# Altium schematic sheet AX5243_schema.SchDoc
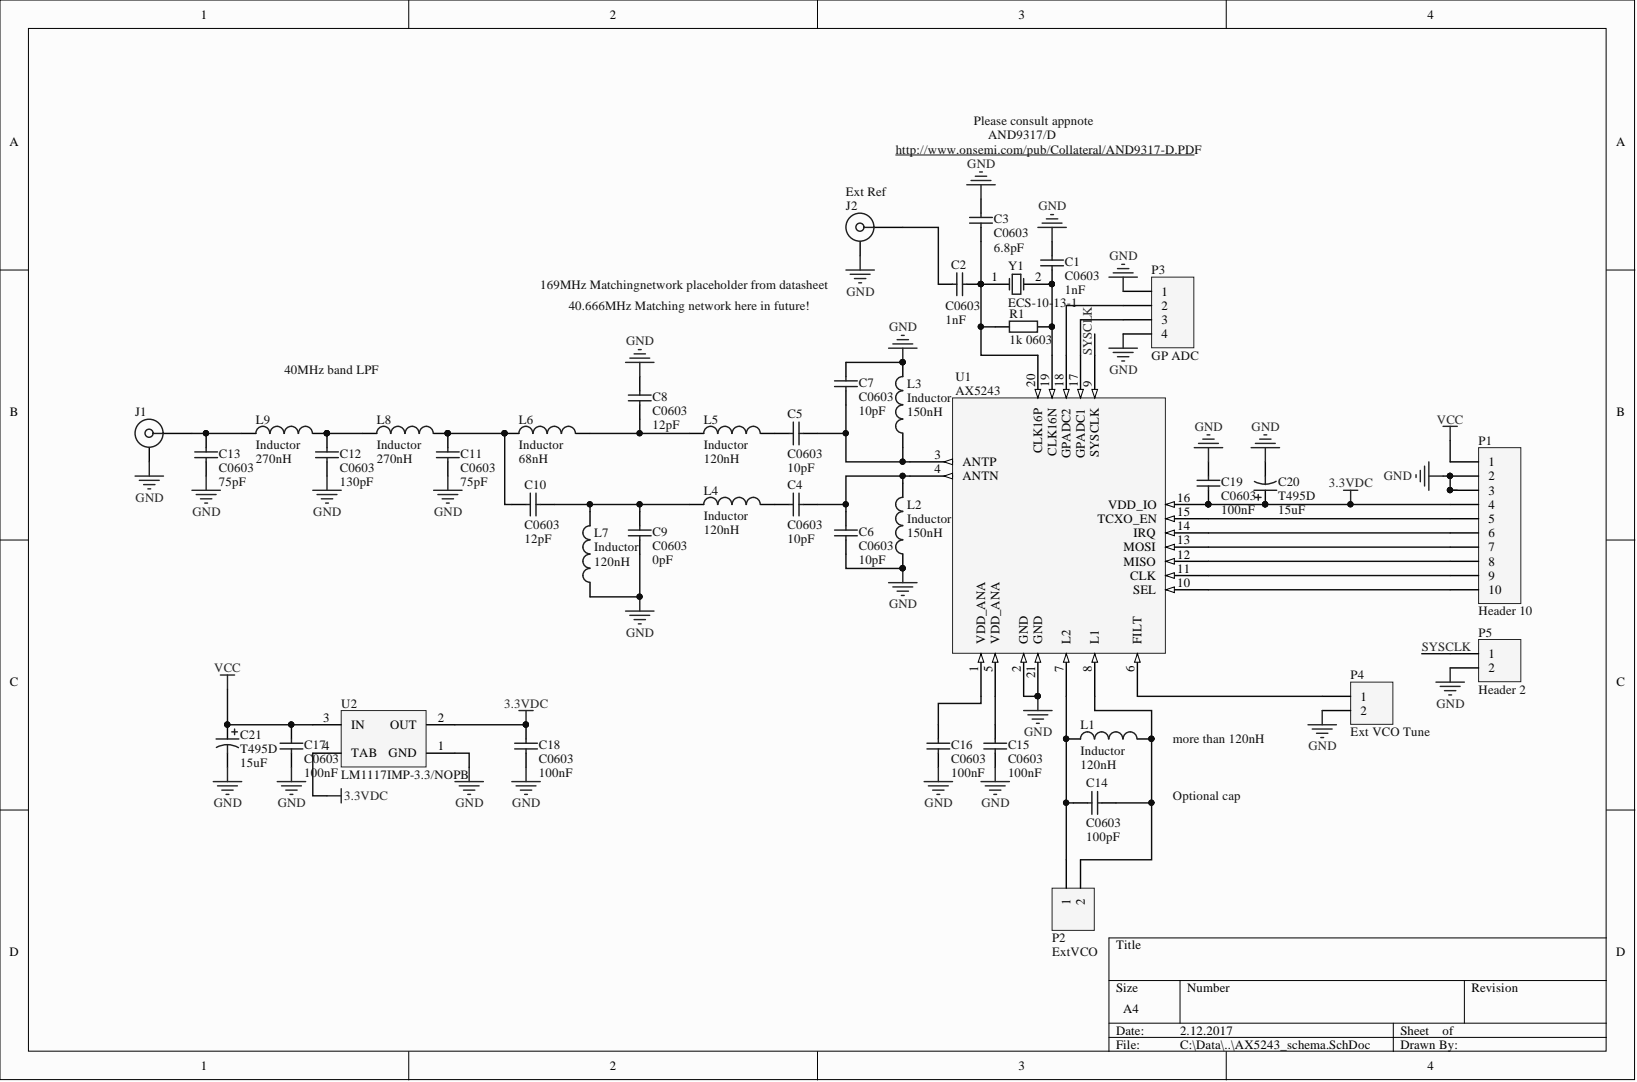
[diagram p0: AX5243_schema.SchDoc]

## Parts
C1  C0603  value 1nF  [0603]
C2  C0603  value 1nF  [0603]
C3  C0603  value 6.8pF  [0603]
C4  C0603  value 10pF  [0603]
C5  C0603  value 10pF  [0603]
C6  C0603  value 10pF  [0603]
C7  C0603  value 10pF  [0603]
C8  C0603  value 12pF  [0603]
C9  C0603  value 0pF  [0603]
C10  C0603  value 12pF  [0603]
C11  C0603  value 75pF  [0603]
C12  C0603  value 130pF  [0603]
C13  C0603  value 75pF  [0603]
C14  C0603  value 100pF  [0603]
C15  C0603  value 100nF  [0603]
C16  C0603  value 100nF  [0603]
C17  C0603  value 100nF  [0603]
C18  C0603  value 100nF  [0603]
C19  C0603  value 100nF  [0603]
C20  T495D  value 15uF  [D]
C21  T495D  value 15uF  [D]
J1  32K145-400L5  [ROS-32K145-400L5_V]  (value not drawn)
J2  32K10A-40ML5  [ROS-32K10A-40ML5_V]  (value not drawn)
L1  Inductor  value 120nH  [INDC1608L]
L2  Inductor  value 150nH  [INDC1608L]
L3  Inductor  value 150nH  [INDC1608L]
L4  Inductor  value 120nH  [INDC1608L]
L5  Inductor  value 120nH  [INDC1608L]
L6  Inductor  value 68nH  [INDC1608L]
L7  Inductor  value 120nH  [INDC1608L]
L8  Inductor  value 270nH  [INDC1608L]
L9  Inductor  value 270nH  [INDC1608L]
P1  Header 10  [HDR1X10]
P2  ExtVCO  [HDR1X2]
P3  GP ADC  [HDR1X4]
P4  Ext VCO Tune  [HDR1X2]
P5  Header 2  [HDR1X2]
R1  1k 0603  [R0603]
U1  AX5243  [QFN20 4,00x4,00mm ON Semi]
U2  LM1117IMP-3.3/NOPB  [MP04A_N]
Y1  ECS-10-13-1  [HC-49U]

## Nets
3.3VDC: C18.2 C19.1 C20.1 P1.4 U1.16(VDD_IO) U2.2(OUT) U2.4(TAB)
GND: C1.2 C3.2 C6.1 C7.2 C8.1 C9.2 C11.1 C12.1 C13.1 C15.1 C16.1 C17.1 C18.1 C19.2 C20.2 C21.2 J1.MP J2.MP L2.1 L3.2 L7.1 P1.2 P1.3 P3.1 P3.4 P4.2 P5.2 U1.2(GND) U1.21(GND) U2.1(GND)
VCC: C17.2 C21.1 P1.1 U2.3(IN)
SYSCLK: P5.1 U1.9(SYSCLK)
NetC1_1: C1.1 R1.2 U1.19(CLK16N) Y1.2
NetC2_1: C2.1 C3.1 R1.1 U1.20(CLK16P) Y1.1
NetC2_2: C2.2 J2.1
NetC4_1: C4.1 L4.2
NetC4_2: C4.2 C6.2 L2.2 U1.4(ANTN)
NetC5_1: C5.1 L5.2
NetC5_2: C5.2 C7.1 L3.1 U1.3(ANTP)
NetC8_2: C8.2 L5.1 L6.2
NetC9_1: C9.1 C10.2 L4.1 L7.2
NetC10_1: C10.1 C11.2 L6.1 L8.2
NetC12_2: C12.2 L8.1 L9.2
NetC13_2: C13.2 J1.1 L9.1
NetC14_1: C14.1 L1.1 P2.1 U1.7(L2)
NetC14_2: C14.2 L1.2 P2.2 U1.8(L1)
NetC15_2: C15.2 U1.5(VDD_ANA)
NetC16_2: C16.2 U1.1(VDD_ANA)
NetP1_5: P1.5 U1.15(TCXO_EN)
NetP1_6: P1.6 U1.14(IRQ)
NetP1_7: P1.7 U1.13(MOSI)
NetP1_8: P1.8 U1.12(MISO)
NetP1_9: P1.9 U1.11(CLK)
NetP1_10: P1.10 U1.10(SEL)
NetP3_2: P3.2 U1.18(GPADC2)
NetP3_3: P3.3 U1.17(GPADC1)
NetP4_1: P4.1 U1.6(FILT)

## Library data of the parts
C1-C4 C6-C19 = C0603: Ceramic Chip Capacitor - Standard; Note: Footprint corresponds to dimensions for bulk cassette packaging. This case size has tightened tolerances.; Code_IPC: 1608 [0603]; Code_JEITA: 0603; Component Kind: Standard; ComponentLink1Description: Manufacturer Link; ComponentLink1URL: http://www.kemet.com/; ComponentLink2Description: Datasheet; ComponentLink2URL: http://www.kemet.com/kemet/web/homepage/kechome.nsf/vapubfilesname/F3102TA.pdf/$file/F3102TA.pdf; DatasheetDocument: Mar-2001; LatestRevisionDate: 19-Mar-2004; LatestRevisionNote: Silkscreen size reduced.; Library Name: KEMET Chip Capacitor.IntLib; PackageDocument: Mar-2001; PackageReference: 0603; Published: 17-Jul-2002; Signal Integrity: C0603; Simulation: CAP; Publisher: Altium Limited
C5 = C0603: Ceramic Chip Capacitor - Standard; Note: Footprint corresponds to dimensions for bulk cassette packaging. This case size has tightened tolerances.; Value: 10pF; Code_IPC: 1608 [0603]; Code_JEITA: 0603; Component Kind: Standard; ComponentLink1Description: Manufacturer Link; ComponentLink1URL: http://www.kemet.com/; ComponentLink2Description: Datasheet; ComponentLink2URL: http://www.kemet.com/kemet/web/homepage/kechome.nsf/vapubfilesname/F3102TA.pdf/$file/F3102TA.pdf; DatasheetDocument: Mar-2001; LatestRevisionDate: 19-Mar-2004; LatestRevisionNote: Silkscreen size reduced.; Library Name: KEMET Chip Capacitor.IntLib; PackageDocument: Mar-2001; PackageReference: 0603; Published: 17-Jul-2002; Signal Integrity: C0603; Simulation: CAP; Publisher: Altium Limited
C20 C21 = T495D: Solid Tantalum Chip Capacitor, Standard T495 Series - Low ESR, Surge Robust; Code_JEITA: 7343-31; Component Kind: Standard; ComponentLink1Description: Manufacturer Link; ComponentLink1URL: http://www.kemet.com/; ComponentLink2Description: Datasheet; ComponentLink2URL: http://www.kemet.com/kemet/web/homepage/kechome.nsf/vapubfilesname/F3102TA.pdf/$file/F3102TA.pdf; DatasheetDocument: Mar-2001; LatestRevisionDate: 19-Mar-2004; LatestRevisionNote: Silkscreen dimensions re-sized.; Library Name: KEMET Chip Capacitor.IntLib; PackageDocument: Mar-2001; PackageReference: D; Published: 17-Jul-2002; Signal Integrity: T495D; Simulation: CAP; Publisher: Altium Limited
J1 = 32K145-400L5: SMA Right Angle Jack, Edge Mount, Up to 18 GHz, -55 to 155 degC; Desgin Note 2: For more information about DRC setting, please look at following webpage, http://techdocs.altium.com/display/SCRT/Design+Rule+for+Edge-Mount+Connectors; Design Note 1: Footprint in library is a generic example - only application specific footprint delivers High Performance; Component Kind: Standard; ComponentLink1Description: Manufacturer URL; ComponentLink1URL: http://www.rosenberger.de; ComponentLink2Description: Datasheet; ComponentLink2URL: http://rosenberger.de/ok/images/documents/db/32K145-400L5.pdf; ComponentLink3Description: Package Specification; ComponentLink3URL: http://rosenberger.de/ok/images/documents/db/32K145-400L5.pdf; ComponentLink4Description: Series Overview / Design Note; ComponentLink4URL: http://rosenberger.de/ok/images/documents/spez/32.pdf; ComponentLink5Description: Webshop / RFQ; ComponentLink5URL: http://www.rosenberger.com/en/contact/sales/; DatasheetVersion: b00; Mounting Technology: Surface Mount; PackageDescription: SMA Right Angle Jack, Edge Mount, 14.25 x 9.5 x 4.46 mm; PackageReference: 32K145-400L5; PackageVersion: b00; PartNumber: 32K145-400L5; Library Name: Rosenberger SMA.IntLib
J2 = 32K10A-40ML5: SMA Straight Jack, Up to 12.4 GHz, -55 to 155 degC; Design Note: Footprint in library is a generic example - only application specific footprint delivers High Performance; Component Kind: Standard; ComponentLink1Description: Manufacturer URL; ComponentLink1URL: http://www.rosenberger.de; ComponentLink2Description: Datasheet; ComponentLink2URL: http://rosenberger.de/ok/images/documents/db/32K10A-40ML5.pdf; ComponentLink3Description: Package Specification; ComponentLink3URL: http://rosenberger.de/ok/images/documents/db/32K10A-40ML5.pdf; ComponentLink4Description: Series Overview / Design Note; ComponentLink4URL: http://rosenberger.de/ok/images/documents/spez/32.pdf; ComponentLink5Description: Webshop / RFQ; ComponentLink5URL: http://www.rosenberger.com/en/contact/sales/; DatasheetVersion: e00; Mounting Technology: Surface Mount; PackageDescription: SMA Straight Jack, Press-Fit, 6.35 x 6.35 x 9.5 mm; PackageReference: 32K10A-40ML5; PackageVersion: e00; PartNumber: 32K10A-40ML5; Library Name: Rosenberger SMA.IntLib
L1 = Inductor: Code_IEC: 1005; Value: 120nH; Code_JEITA: 0402; Component Kind: Standard; Description: Inductor; LatestRevisionDate: 29-May-2009; LatestRevisionNote: IPC-7351 Footprint Added.; Library Name: Miscellaneous Devices.IntLib; PackageDescription: Chip Inductor; PackageReference: 0402-A; Published: 8-Jun-2000; Signal Integrity: Inductor; Simulation: INDUCTOR; Publisher: Altium Limited
L2-L5 L7-L9 = Inductor: Code_IEC: 1005; Code_JEITA: 0402; Component Kind: Standard; Description: Inductor; LatestRevisionDate: 29-May-2009; LatestRevisionNote: IPC-7351 Footprint Added.; Library Name: Miscellaneous Devices.IntLib; PackageDescription: Chip Inductor; PackageReference: 0402-A; Published: 8-Jun-2000; Signal Integrity: Inductor; Simulation: INDUCTOR; Publisher: Altium Limited
L6 = Inductor: Code_IEC: 1005; Value: 68nH; Code_JEITA: 0402; Component Kind: Standard; Description: Inductor; LatestRevisionDate: 29-May-2009; LatestRevisionNote: IPC-7351 Footprint Added.; Library Name: Miscellaneous Devices.IntLib; PackageDescription: Chip Inductor; PackageReference: 0402-A; Published: 8-Jun-2000; Signal Integrity: Inductor; Simulation: INDUCTOR; Publisher: Altium Limited
P1 = Header 10: Header, 10-Pin; Component Kind: Standard; LatestRevisionDate: 17-Jul-2002; LatestRevisionNote: Re-released for DXP Platform.; Library Name: Miscellaneous Connectors.IntLib; Signal Integrity: Connector; Publisher: Altium Limited
P2 P4 P5 = Header 2: Header, 2-Pin; Component Kind: Standard; LatestRevisionDate: 17-Jul-2002; LatestRevisionNote: Re-released for DXP Platform.; Library Name: Miscellaneous Connectors.IntLib; Signal Integrity: Connector; Publisher: Altium Limited
P3 = Header 4: Header, 4-Pin; Component Kind: Standard; LatestRevisionDate: 17-Jul-2002; LatestRevisionNote: Re-released for DXP Platform.; Library Name: Miscellaneous Connectors.IntLib; Signal Integrity: Connector; Publisher: Altium Limited
U1 = AX5243: Data Tranceiver 27-1050MHz; Current - Receiving: 11mA; Current - Transmitting: 48mA; Data Rate: 125Kbps; Frequency: 27MHz; Interface: SPI; Max Frequency: 1.05GHz; Max Supply Voltage: 3.6V; Min Supply Voltage: 1.8V; Sensitivity: -137dBm; Case/Package: QFN; Component Kind: Standard; ComponentLink1Description: http://www.onsemi.com/pub/Collateral/AX5243-D.PDF; ComponentLink1URL: http://www.onsemi.com/pub/Collateral/AX5243-D.PDF; ComponentLink2Description: http://www.farnell.com/datasheets/2009300.pdf; ComponentLink2URL: http://www.farnell.com/datasheets/2009300.pdf; Lead Free: Lead Free; Manufacturer 2: ON Semiconductor; Manufacturer Part Number 2: AX5243-1-TA05; Max Operating Temperature: 85°C; Min Operating Temperature: -40°C; Mount: Surface Mount; Number of Pins: 20; Packaging: Tape and Reel; Pricing 2: 1=2.34, 10=2.117, 100=1.701 (USD); REACH SVHC: No SVHC; Stock 2: 0; Supplier 1: Mouser; Supplier 2: Digi-Key; Supplier Part Number 1: 863-AX5243-1-TA05; Supplier Part Number 2: AX5243-1-TA05OSCT-ND; Library Name: ftg_lib.IntLib
U2 = LM1117IMP-3.3/NOPB: 800mA Low-Dropout Linear Regulator, 4-pin SOT-223, Pb-Free; Manufacturer: National Semiconductor; CompType: LDO IC; High-Reliability: Y; PackageTopMarking: XYTT*N05B; PowerWise: N; Code_IPC: SOT223-4; Code_JEDEC: TO-261-AA; Component Kind: Standard; ComponentLink1Description: Manufacturer URL; ComponentLink1URL: http://www.national.com/; ComponentLink2Description: LM1117 Datasheet; ComponentLink2URL: http://www.national.com/ds/LM/LM1117.pdf; ComponentLink3Description: LM1117 Web Page; ComponentLink3URL: http://www.national.com/pf/LM/LM1117.html; ComponentLink4Description: MP04A Package Page; ComponentLink4URL: http://www.national.com/packaging/folders/mp04a.html; DatasheetVersion: Apr-2006; Library Name: National Semiconductor LDO.IntLib; PackageDescription: Molded SOT-223, 6.50 x 3.56 x 1.6mm Body, 4 Lead, 2.3mm Pitch; PackageReference: MP04A; PackageVersion: revB, Apr-2003; PartNumber: LM1117IMP-3.3/NOPB; PinCount: 4; RoHS: Y
Y1 = ECS-10-13-1: Crystal Oscillator; Component Kind: Standard; ComponentLink1Description: Manufacturer Link; ComponentLink1URL: http://www.ecsxtal.com/; ComponentLink2Description: Datasheet; ComponentLink2URL: http://www.ecsxtal.com/pdf2/HC49U.PDF; DatasheetDocument: 18-Apr-2000; Library Name: ECS Crystal Oscillator.IntLib; PackageReference: HC-49U; Published: 17-Jul-2002; Simulation: XTAL; Publisher: Altium Limited
R1 = 1k 0603: Component Kind: Standard; Library Name: ftg_lib.IntLib
